# Altium schematic sheet Schematic Prints("All Documents",Physical)
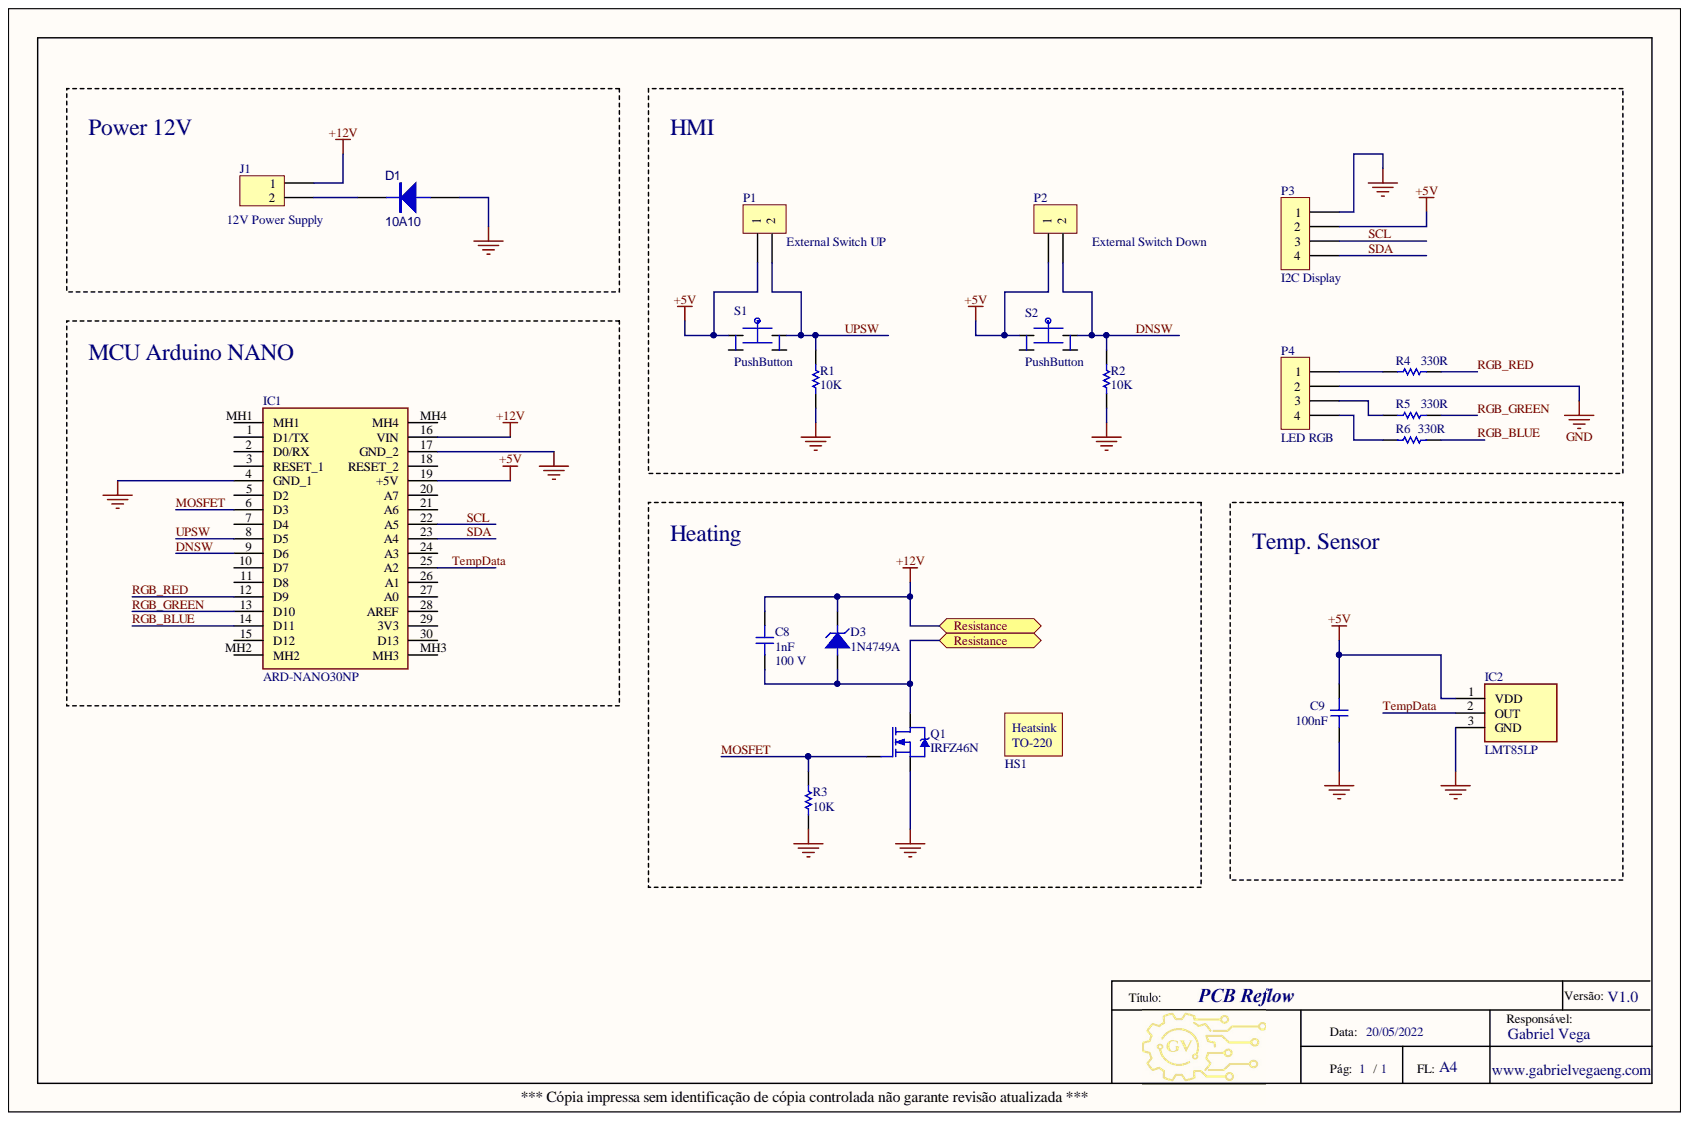
[diagram p0: Schematic Prints("All Documents",Physical)]

## Parts
C8  1nF  [C100-200-100]
C9  100nF  [C100-200-100]
D1  10A10  [DIODE-8.6]
D3  1N4749A  [DO-41-Glass]
HS1  HS-TO-220  [HS-TO-220-180847]  (value not drawn)
IC1  ARD-NANO30NP  [ARDNANO30NP]
IC2  LMT85LP  [LMT85LP]
J1  12V Power Supply  [PHOENIX_1X2 - 180°]
P1  External Switch UP  [HDR-M-1x2-2.54mm-180-9.2mm]
P2  External Switch Down  [HDR-M-1x2-2.54mm-180-9.2mm]
P3  I2C Display  [HDR-M-1x4-2.54mm-180-9.2mm]
P4  LED RGB  [HDR-M-1x4-2.54mm-180-9.2mm]
Q1  IRFZ46N  [TO-220/123]
R1  10K  [R400-2.0mm]
R2  10K  [R400-2.0mm]
R3  10K  [R400-2.0mm]
R4  330R  [R400-2.0mm]
R5  330R  [R400-2.0mm]
R6  330R  [R400-2.0mm]
S1  PushButton  [DTS-6.0mm-6.0mm-THT]
S2  PushButton  [DTS-6.0mm-6.0mm-THT]

## Nets
+5V: C9.2 IC1.19(+5V) IC2.1 P1.1 P2.1 P3.2 S1.1 S2.1
+12V: C8.1 C8.2 D3.A D3.K IC1.16(VIN) J1.1 Q1.D
GND: C9.1 D1.1 IC1.4(GND_1) IC1.17(GND_2) IC2.3 P3.1 P4.2 Q1.S R1.1 R2.1 R3.1
DNSW: IC1.9(D6) P2.2 R2.2 S2.3
MOSFET: IC1.6(D3) Q1.G R3.2
RGB_BLUE: IC1.14(D11) R6.1
RGB_GREEN: IC1.13(D10) R5.1
RGB_RED: IC1.12(D9) R4.1
SCL: IC1.22(A5) P3.3
SDA: IC1.23(A4) P3.4
TempData: IC1.25(A2) IC2.2
UPSW: IC1.8(D5) P1.2 R1.2 S1.3
NetD1_2: D1.2 J1.2
NetIC1_1: IC1.1(D1/TX)
NetIC1_2: IC1.2(D0/RX)
NetIC1_3: IC1.3(RESET_1)
NetIC1_5: IC1.5(D2)
NetIC1_7: IC1.7(D4)
NetIC1_10: IC1.10(D7)
NetIC1_11: IC1.11(D8)
NetIC1_15: IC1.15(D12)
NetIC1_18: IC1.18(RESET_2)
NetIC1_20: IC1.20(A7)
NetIC1_21: IC1.21(A6)
NetIC1_24: IC1.24(A3)
NetIC1_26: IC1.26(A1)
NetIC1_27: IC1.27(A0)
NetIC1_28: IC1.28(AREF)
NetIC1_29: IC1.29(3V3)
NetIC1_30: IC1.30(D13)
NetIC1_MH1: IC1.MH1
NetIC1_MH2: IC1.MH2
NetIC1_MH3: IC1.MH3
NetIC1_MH4: IC1.MH4
NetP4_1: P4.1 R4.2
NetP4_3: P4.3 R5.2
NetP4_4: P4.4 R6.2
NetS1_2: S1.2
NetS1_4: S1.4
NetS2_2: S2.2
NetS2_4: S2.4

## Library data of the parts
C8 C9 = Capacitor: Voltage: 100 V; Published: 8-Jun-2000; PackageReference: RAD-0.3; LatestRevisionNote: Re-released for DXP Platform.; LatestRevisionDate: 17-Jul-2002; Description: Capacitor; Component Kind: Standard; Publisher: Altium Limited; Library Name: GPDSE_discrete.SchLib
D1 = 10A10: Diodo; Component Kind: Standard; Library Name: GPDSE_diode.SchLib
D3 = 1N4749A: Zener Diode; Zener Voltage (Vz): 24 V; Zener Current (Iz): 38 mA; Power Dissipation (Pd): 1.3 W; Forward Voltage (Vf): 1.2 V; Component Kind: Standard; Library Name: GPDSE_diode.SchLib
HS1 = HS-TO-220: Heatsink TO-220; Component Kind: Standard; Library Name: GPDSE.IntLib
IC1 = ARD-NANO30NP: Integrated Circuit; Manufacturer_Name: Gravitech; Mouser Price/Stock: https://www.mouser.co.uk/ProductDetail/Gravitech/ARD-NANO30NP?qs=Vxac6xGyzPlh7in3DWNTbQ%3D%3D; Mouser Part Number: 992-ARD-NANO30NP; Manufacturer_Part_Number: ARD-NANO30NP; Height: 5mm; Datasheet Link: http://www.mouser.com/datasheet/2/595/Arduino_Nano3_0-220057.pdf; Component Kind: Standard
IC2 = LMT85LP: Integrated Circuit; Manufacturer_Name: Texas Instruments; Mouser Price/Stock: https://www.mouser.co.uk/ProductDetail/Texas-Instruments/LMT85LP?qs=U0ECReq1GB%2FnA9zQwQMysg%3D%3D; Mouser Part Number: 595-LMT85LP; Manufacturer_Part_Number: LMT85LP; Height: 5.34mm; Datasheet Link: https://www.ti.com/lit/ds/symlink/lmt85.pdf?HQS=dis-mous-null-mousermode-dsf-pf-null-wwe&ts=1635242341074&ref_url=https%253A%252F%252Fwww.mouser.de%252F; Component Kind: Standard; Arrow Price/Stock: https://www.arrow.com/en/products/lmt85lp/texas-instruments?region=nac; Arrow Part Number: LMT85LP
J1 = Phoenix_1x2: Phoenix connector, 1 Lines, 2 Columns, 2 Pins; Component Kind: Standard; Library Name: GDPSE_connector.SchLib
P1 P2 = Header_1x2: Header, 1 Line, 2 Columns, 2 Pins; LatestRevisionNote: Re-released for DXP Platform.; LatestRevisionDate: 17-Jul-2002; Component Kind: Standard; Publisher: Altium Limited; Library Name: GDPSE_connector.SchLib
P3 P4 = Header_1x4: Header, 1 Line, 4 Columns, 4 Pins; LatestRevisionNote: Re-released for DXP Platform.; LatestRevisionDate: 17-Jul-2002; Component Kind: Standard; Publisher: Altium Limited; Library Name: GDPSE_connector.SchLib
Q1 = IRFZ46N: HEXFET Power MOSFET; Turn-On Delay (tdon): 14 ns; Turn-Off Delay (Tdoff): 52 ns; Static Drain-to-Source On-Resistance (Rdson): 16.5 mR; Rise Time (Rt): 76 ns; Power Dissipation (Pd): 107 W; Gate-to-Source Voltage (Vgs): 20 V; Fall Time (Tf): 57 ns; Drain Current Peak (Idp): 180 A; Drain Current (Id): 53 A; Component Kind: Standard; Library Name: GPDSE.IntLib
R1-R3 = Resistor: Tolerance: 5%; Power: 1/4 W; Published: 8-Jun-2000; PackageReference: AXIAL-0.3; LatestRevisionNote: Re-released for DXP Platform.; LatestRevisionDate: 17-Jul-2002; Description: Resistor; Component Kind: Standard; Publisher: Altium Limited; Library Name: GPDSE.IntLib
R4-R6 = Resistor: Tolerance: 5%; Published: 8-Jun-2000; PackageReference: AXIAL-0.3; LatestRevisionNote: Re-released for DXP Platform.; LatestRevisionDate: 17-Jul-2002; Description: Resistor; Component Kind: Standard; Publisher: Altium Limited; Library Name: GPDSE.IntLib
S1 S2 = PushButton_4: Tactile Switch SPST 4 pins; Component Kind: Standard; Library Name: GPDSE_discrete.SchLib
